# Altium schematic sheet FlipClockController.SchDoc
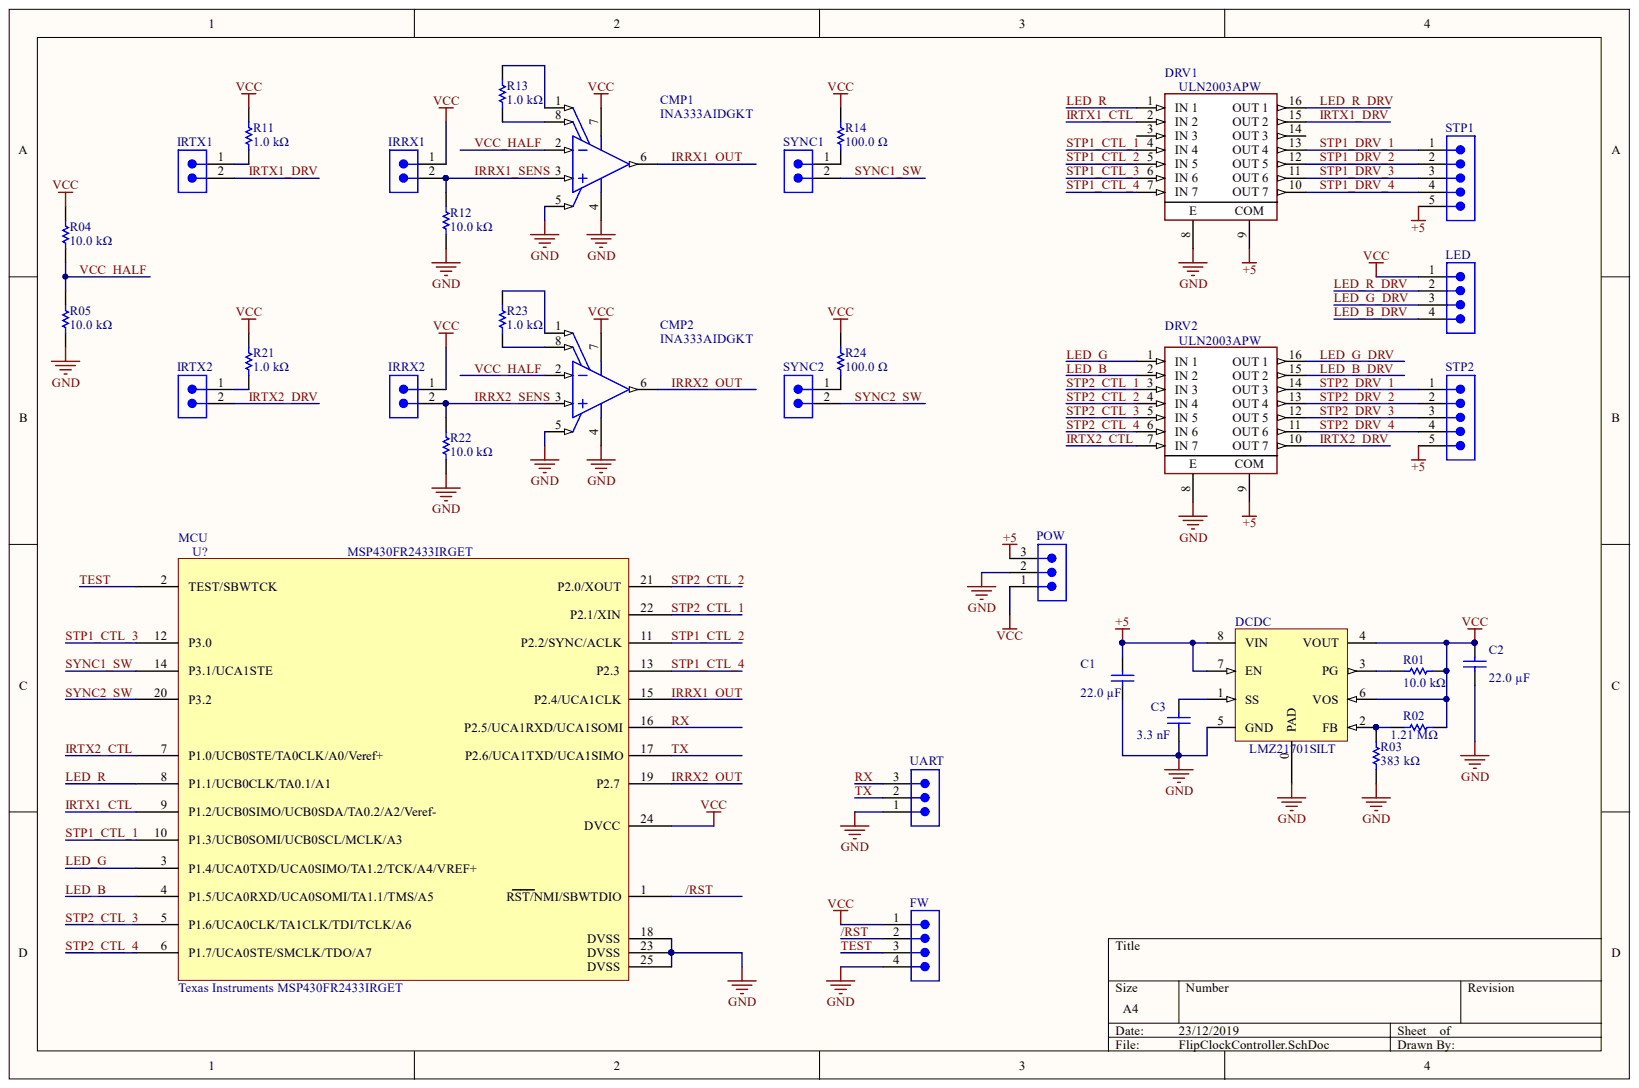
[diagram p0: FlipClockController.SchDoc]

## Parts
C1  Capacitance: 22.0 µF  [PCB-8en9x60tm95q6hri2c8x-1]  (hidden comment: cap 22uF)
C2  cap 22uF  [PCB-8en9x60tm95q6hri2c8x-1]  (value not drawn)
C3  Capacitance: 3.3 nF  [CAPC1608X92X38LL15T08]  (hidden comment: Vitramon VJ0603Y332KXAAC)
CMP1  INA333AIDGKT  [TI-DGK8_L]
CMP2  INA333AIDGKT  [TI-DGK8_L]
DCDC  LMZ21701SILT  [PCB-20086818-1]
DRV1  ULN2003APW  [TSSOP16]
DRV2  ULN2003APW  [TSSOP16]
FW  Samtec TSW-104-05-G-S  [1X4]  (value not drawn)
IRRX1  Samtec TSW-102-05-G-S  [1X2]  (value not drawn)
IRRX2  Samtec TSW-102-05-G-S  [1X2]  (value not drawn)
IRTX1  Samtec TSW-102-05-G-S  [1X2]  (value not drawn)
IRTX2  Samtec TSW-102-05-G-S  [1X2]  (value not drawn)
LED  Samtec TSW-104-05-G-S  [1X4]  (value not drawn)
MCU  Texas Instruments MSP430FR2433IRGET  [PCB-bcs2lmkfqlrqmvty3otx-1]
POW  CMP-668703-2  [SMTC-TSW-103-07-X-S]  (value not drawn)
R01  Resistance: 10.0 kΩ  [RESC1608X55X30LL20T10]  (hidden comment: KOA Speer RK73B1JTTD103J)
R02  Resistance: 1.21 MΩ  [RESC1608X55X25ML10T15]  (hidden comment: Yageo RC0603FR-071M21L)
R03  Resistance: 383 kΩ  [RESC1608X55X25LL10T15]  (hidden comment: Yageo RT0603FRE07383KL)
R04  KOA Speer RK73B1JTTD103J  [RESC1608X55X30LL20T10]  (value not drawn)
R05  KOA Speer RK73B1JTTD103J  [RESC1608X55X30LL20T10]  (value not drawn)
R11  Resistance: 1.0 kΩ  [RESC1608X55X30LL20T10]  (hidden comment: KOA Speer RK73H1JTTD1001F)
R12  KOA Speer RK73B1JTTD103J  [RESC1608X55X30LL20T10]  (value not drawn)
R13  Resistance: 1.0 kΩ  [RESC1608X55X30LL20T10]  (hidden comment: KOA Speer RK73H1JTTD1001F)
R14  KOA Speer RK73H1JTTD1000F  [RESC1608X55X30LL20T10]  (value not drawn)
R21  Resistance: 1.0 kΩ  [RESC1608X55X30LL20T10]  (hidden comment: KOA Speer RK73H1JTTD1001F)
R22  KOA Speer RK73B1JTTD103J  [RESC1608X55X30LL20T10]  (value not drawn)
R23  Resistance: 1.0 kΩ  [RESC1608X55X30LL20T10]  (hidden comment: KOA Speer RK73H1JTTD1001F)
R24  KOA Speer RK73H1JTTD1000F  [RESC1608X55X30LL20T10]  (value not drawn)
STP1  Samtec TSW-105-05-G-S  [1X5]  (value not drawn)
STP2  Samtec TSW-105-05-G-S  [1X5]  (value not drawn)
SYNC1  Samtec TSW-102-05-G-S  [1X2]  (value not drawn)
SYNC2  Samtec TSW-102-05-G-S  [1X2]  (value not drawn)
UART  TSW-103-07-F-S  [SMTC-TSW-103-07-X-S]  (value not drawn)

## Nets
+5: C1.1 DCDC.7(EN) DCDC.8(VIN) DRV1.9 DRV2.9 POW.3 STP1.5 STP2.5
GND: C1.2 C2.2 C3.2 CMP1.4 CMP1.5 CMP2.4 CMP2.5 DCDC.0(PAD) DCDC.5(GND) DRV1.8(E) DRV2.8(E) FW.4 MCU.18(DVSS) MCU.23(DVSS) MCU.25(DVSS) POW.2 R03.1 R05.1 R12.1 R22.1 UART.1
VCC: C2.1 CMP1.7 CMP2.7 DCDC.4(VOUT) DCDC.6(VOS) FW.1 IRRX1.1 IRRX2.1 LED.1 MCU.24(DVCC) POW.1 R01.1 R02.2 R04.2 R11.2 R14.2 R21.2 R24.2
/RST: FW.2 MCU.1(RST/NMI/SBWTDIO)
IRRX1_OUT: CMP1.6 MCU.15(P2.4/UCA1CLK)
IRRX1_SENS: CMP1.3 IRRX1.2 R12.2
IRRX2_OUT: CMP2.6 MCU.19(P2.7)
IRRX2_SENS: CMP2.3 IRRX2.2 R22.2
IRTX1_CTL: DRV1.2(IN_2) MCU.9(P1.2/UCB0SIMO/UCB0SDA/TA0.2/A2/Veref-)
IRTX1_DRV: DRV1.15(OUT_2) IRTX1.2
IRTX2_CTL: DRV2.7(IN_7) MCU.7(P1.0/UCB0STE/TA0CLK/A0/Veref+)
IRTX2_DRV: DRV2.10(OUT_7) IRTX2.2
LED_B: DRV2.2(IN_2) MCU.4(P1.5/UCA0RXD/UCA0SOMI/TA1.1/TMS/A5)
LED_B_DRV: DRV2.15(OUT_2) LED.4
LED_G: DRV2.1(IN_1) MCU.3(P1.4/UCA0TXD/UCA0SIMO/TA1.2/TCK/A4/VREF+)
LED_G_DRV: DRV2.16(OUT_1) LED.3
LED_R: DRV1.1(IN_1) MCU.8(P1.1/UCB0CLK/TA0.1/A1)
LED_R_DRV: DRV1.16(OUT_1) LED.2
RX: MCU.16(P2.5/UCA1RXD/UCA1SOMI) UART.3
STP1_CTL_1: DRV1.4(IN_4) MCU.10(P1.3/UCB0SOMI/UCB0SCL/MCLK/A3)
STP1_CTL_2: DRV1.5(IN_5) MCU.11(P2.2/SYNC/ACLK)
STP1_CTL_3: DRV1.6(IN_6) MCU.12(P3.0)
STP1_CTL_4: DRV1.7(IN_7) MCU.13(P2.3)
STP1_DRV_1: DRV1.13(OUT_4) STP1.1
STP1_DRV_2: DRV1.12(OUT_5) STP1.2
STP1_DRV_3: DRV1.11(OUT_6) STP1.3
STP1_DRV_4: DRV1.10(OUT_7) STP1.4
STP2_CTL_1: DRV2.3(IN_3) MCU.22(P2.1/XIN)
STP2_CTL_2: DRV2.4(IN_4) MCU.21(P2.0/XOUT)
STP2_CTL_3: DRV2.5(IN_5) MCU.5(P1.6/UCA0CLK/TA1CLK/TDI/TCLK/A6)
STP2_CTL_4: DRV2.6(IN_6) MCU.6(P1.7/UCA0STE/SMCLK/TDO/A7)
STP2_DRV_1: DRV2.14(OUT_3) STP2.1
STP2_DRV_2: DRV2.13(OUT_4) STP2.2
STP2_DRV_3: DRV2.12(OUT_5) STP2.3
STP2_DRV_4: DRV2.11(OUT_6) STP2.4
SYNC1_SW: MCU.14(P3.1/UCA1STE) SYNC1.2
SYNC2_SW: MCU.20(P3.2) SYNC2.2
TEST: FW.3 MCU.2(TEST/SBWTCK)
TX: MCU.17(P2.6/UCA1TXD/UCA1SIMO) UART.2
VCC_HALF: CMP1.2 CMP2.2 R04.1 R05.2
NetC3_1: C3.1 DCDC.1(SS)
NetCMP1_1: CMP1.1 R13.1
NetCMP1_8: CMP1.8 R13.2
NetCMP2_1: CMP2.1 R23.1
NetCMP2_8: CMP2.8 R23.2
NetDCDC_2: DCDC.2(FB) R02.1 R03.2
NetDCDC_3: DCDC.3(PG) R01.2
NetIRTX1_1: IRTX1.1 R11.1
NetIRTX2_1: IRTX2.1 R21.1
NetR14_1: R14.1 SYNC1.1
NetR24_1: R24.1 SYNC2.1

Not connected: DRV1 3(IN_3) 14(OUT_3)

## Library data of the parts
C1 = CMP-11774615-7: SMD Multilayer Ceramic Capacitor, GRM Series, 22 F, 20%, X5R, 6.3 V, 0603 [1608 Metric]; Manufacturer Part Number: GRM188R60J226MEA0D; Case/Package (SI): 1608; Lead-Free Status: Lead Free; Voltage Rating (DC): 6.30 V; Capacitance Tolerance: ±20%; Case/Package: 0603; Component Kind: Standard; Library Name: CommunityVault; Lifecycle Status: Active; Mounting Style: Surface Mount; Octopart URL: http://octopart.com/grm188r60j226mea0d-murata-21407286; Packaging: Cut Tape (CT); RoHS: Compliant; Size-Length: 1.60 mm; Size-Width: 800 µm
C2 = CMP-11774615-7: SMD Multilayer Ceramic Capacitor, GRM Series, 22 F, 20%, X5R, 6.3 V, 0603 [1608 Metric]; Manufacturer Part Number: GRM188R60J226MEA0D; Capacitance: 22.0 µF; Case/Package (SI): 1608; Lead-Free Status: Lead Free; Voltage Rating (DC): 6.30 V; Capacitance Tolerance: ±20%; Case/Package: 0603; Component Kind: Standard; Library Name: CommunityVault; Lifecycle Status: Active; Mounting Style: Surface Mount; Octopart URL: http://octopart.com/grm188r60j226mea0d-murata-21407286; Packaging: Cut Tape (CT); RoHS: Compliant; Size-Length: 1.60 mm; Size-Width: 800 µm
C3 = CMP-150069-2: Multilayer Ceramic Capacitors MLCC - SMD/SMT 3300pF 50volts X7R 0.1; Capacitance Tolerance: ±10%; Voltage Rating (DC): 50.0 V; Case/Package: 0603; Component Kind: Standard; Dielectric Characteristic: X7R; Library Name: CommunityVault; Manufacturer Part Number: VJ0603Y332KXAAC; Number of Pins: 2; OctopartID: 4ec085be22f09107; Octopart Url: https://octopart.com/vj0603y332kxaac-vishay+vitramon-41175382; RoHS: Compliant
CMP1 CMP2 = CMP-1154636-2: Low Power, Precision Instrumentation Amplifier, -40 to 125 degC, 8-pin SOP (DGK8), Green (RoHS & no Sb/Br); Bandwidth: 150000.0 Hz; Lead-Free Status: Lead Free; Number of Channels: 1; Quiescent Current: 5e-05 A; Slew Rate: 0.16 V/μs; Component Kind: Standard; Library Name: CommunityVault; Lifecycle Status: Active; Manufacturer Part Number: INA333AIDGKT; Mounting Style: Surface Mount; Number of Pins: 8; OctopartID: 43678a1382239ca0; Octopart Url: https://octopart.com/ina333aidgkt-texas+instruments-8315938; Packaging: Tape & Reel (TR); REACH SVHC Compliance: No SVHC; RoHS: Compliant; Supply Current: 5e-05 A
DCDC = CMP-20086818-9: DC/DC CONVERTER, SYNCHRONOUS BUCK, 2.5MHZ, USIP; DC / DC Converter Type:Non Isolated POL; Input Voltage Min:3V; Input Voltage Max:17V; No. of Outputs:1; DC / DC Converter IC Case: SIP; No. of Pins:8;; Component Kind: Standard; Library Name: CommunityVault
DRV1 DRV2 = CMP-19354101-3: High-Voltage, High-Current Darlington Transistor Arrays 16-TSSOP -20 to 70; Drivers Per Package: 7; Case/Package: PDIP, TSSOP, SOIC; Component Kind: Standard; Library Name: CommunityVault; Mounting Style: Surface Mount; REACH SVHC Compliance: No SVHC; RoHS: Compliant
FW LED = CMP-4485475-2: CONN HEADER 4POS .100" SGL GOLD; Gender: Male; Lead-Free Status: Lead Free; Number of Contacts: 4; Pin Pitch: 0.00254 m; Component Kind: Standard; Contact Material: Phosphor Bronze; Contact Plating: Gold; Housing Color: Black; Housing Material: Plastic; Library Name: CommunityVault; Manufacturer Part Number: TSW-104-05-G-S; Mounting Style: Through Hole; Number of Positions: 4; Number of Rows: 1; OctopartID: 1a1ed3101b5fc5d9; Octopart Url: https://octopart.com/tsw-104-05-g-s-samtec-271399; REACH SVHC Compliance: No SVHC; RoHS: Compliant; Size-Height: 0.00254 m; Size-Length: 0.01016 m; Size-Width: 0.00254 m
IRRX1 IRRX2 IRTX1 IRTX2 SYNC1 SYNC2 = CMP-4500545-3: CONN HEADER 2POS .100" SGL GOLD; Gender: Male; Lead-Free Status: Lead Free; Number of Contacts: 2; Pin Pitch: 0.00254 m; Component Kind: Standard; Contact Material: Phosphor Bronze; Contact Plating: Gold; Housing Color: Black; Housing Material: Plastic; Library Name: CommunityVault; Manufacturer Part Number: TSW-102-05-G-S; Mounting Style: Through Hole; Number of Positions: 2; Number of Rows: 1; OctopartID: cadc03d2cb9f151b; Octopart Url: https://octopart.com/tsw-102-05-g-s-samtec-271397; REACH SVHC Compliance: No SVHC; RoHS: Compliant; Size-Height: 0.00254 m; Size-Length: 0.00508 m; Size-Width: 0.00254 m
MCU = CMP-694ea3beafcfb689-8: 16 MHz Ultra-Low-Power Microcontroller with 16 KB FRAM, 4 KB RAM, 10-bit ADC, 19 IO, 4 16-bit Timers 24-VQFN -40 to 85; Frequency: 16.0 MHz; Lead-Free Status: Lead Free; RAM Memory Size: 19968 B; Component Kind: Standard; Library Name: CommunityVault; Lifecycle Status: Pre-Release; Mounting Style: Surface Mount; OctopartID: 694ea3beafcfb689; Operating Temperature: -40.0 °C to 85.0 °C; RoHS: Compliant
POW = CMP-668703-2: 0.025" SQ Post Header, Through-hole, Vertical, -55 to 125 degC, 2.54 mm Pitch, 3-Pin, Male, RoHS; Manufacturer Part Number: TSW-103-07-F-S; Number of Contacts: 3; Pin Pitch: 0.00254 m; Component Kind: Standard; Contact Material: Phosphor Bronze; Contact Plating: Gold; Gender: Male; Library Name: CommunityVault; Mounting Style: Through Hole; Number of Rows: 1; OctopartID: 98e25129adb64725; Octopart Url: https://octopart.com/tsw-103-07-f-s-samtec-12652594; REACH SVHC Compliance: No SVHC; RoHS: Compliant
R01 = CMP-2823407-2: Res Thick Film 0603 10K Ohm 5% 1/10W ±200ppm/C Molded SMD Punched Paper T/R; Lead-Free Status: Lead Free; Power Rating: 0.1 W; Temperature Coefficient: 200.0 ppm/°C; Voltage Rating (DC): 50.0 V; Case/Package: 0603; Component Kind: Standard; Library Name: CommunityVault; Manufacturer Part Number: RK73B1JTTD103J; Mounting Style: Surface Mount; OctopartID: a3d76afc89477c10; Octopart Url: https://octopart.com/rk73b1jttd103j-koa+speer-2166774; Resistance Tolerance: ±5%; RoHS: Compliant; Size-Length: 0.0016 m; Size-Width: 0.0008 m
R02 = CMP-1853549-2: Thick Film Resistors 1.21M OHM 1%; Lead-Free Status: Lead Free; Power Rating: 0.1 W; Voltage Rating (DC): 50.0 V; Case/Package: 0603; Component Kind: Standard; Library Name: CommunityVault; Manufacturer Part Number: RC0603FR-071M21L; Mounting Style: Surface Mount; OctopartID: a0e869f7dbd07f2f; Octopart Url: https://octopart.com/rc0603fr-071m21l-yageo-1400414; Resistance Tolerance: ±1%; RoHS: Compliant; Size-Height: 0.00045 m; Size-Length: 0.0016 m; Size-Width: 0.0008 m
R03 = CMP-7962353-2: Thin Film Resistors 1/10W 383K ohm 1% 50ppm; Case/Package: 0603; Component Kind: Standard; Library Name: CommunityVault; Manufacturer Part Number: RT0603FRE07383KL; Mounting Style: Surface Mount; OctopartID: 307af8f70362efbd; Octopart Url: https://octopart.com/rt0603fre07383kl-yageo-25630334; Resistance Tolerance: ±1%; RoHS: Compliant
R04 R05 R12 R22 = CMP-2823407-2: Res Thick Film 0603 10K Ohm 5% 1/10W ±200ppm/C Molded SMD Punched Paper T/R; Lead-Free Status: Lead Free; Power Rating: 0.1 W; Resistance: 10.0 kΩ; Temperature Coefficient: 200.0 ppm/°C; Voltage Rating (DC): 50.0 V; Case/Package: 0603; Component Kind: Standard; Library Name: CommunityVault; Manufacturer Part Number: RK73B1JTTD103J; Mounting Style: Surface Mount; OctopartID: a3d76afc89477c10; Octopart Url: https://octopart.com/rk73b1jttd103j-koa+speer-2166774; Resistance Tolerance: ±5%; RoHS: Compliant; Size-Length: 0.0016 m; Size-Width: 0.0008 m
R11 R13 R21 R23 = CMP-2823528-2: Res Thick Film 0603 1K Ohm 1% 0.1W(1/10W) ±100ppm/C Molded SMD Automotive Punched Paper T/R; Lead-Free Status: Lead Free; Temperature Coefficient: 100.0 ppm/°C; Voltage Rating (DC): 50.0 V; Case/Package: 0603; Component Kind: Standard; Library Name: CommunityVault; Manufacturer Part Number: RK73H1JTTD1001F; Mounting Style: Surface Mount; OctopartID: e6af2f84cdaf3968; Octopart Url: https://octopart.com/rk73h1jttd1001f-koa+speer-2166374; Power Rating: 0.1 W; Resistance Tolerance: ±1%; RoHS: Compliant; Size-Length: 0.0016 m; Size-Width: 0.0008 m
R14 R24 = CMP-2823347-2: Res Thick Film 0603 100 Ohm 1% 0.1W(1/10W) ±100ppm/C Molded SMD Automotive Punched Paper T/R; Lead-Free Status: Lead Free; Resistance: 100.0 Ω; Temperature Coefficient: 100.0 ppm/°C; Voltage Rating (DC): 50.0 V; Case/Package: 0603; Component Kind: Standard; Library Name: CommunityVault; Manufacturer Part Number: RK73H1JTTD1000F; Mounting Style: Surface Mount; OctopartID: 224beb600e0cfd8c; Octopart Url: https://octopart.com/rk73h1jttd1000f-koa+speer-2165025; Power Rating: 0.1 W; Resistance Tolerance: ±1%; RoHS: Compliant; Size-Length: 0.0016 m; Size-Width: 0.0008 m
STP1 STP2 = CMP-3921831-3: CONN HEADER 5POS .100" SGL GOLD; Gender: Male; Lead-Free Status: Lead Free; Number of Contacts: 5; Pin Pitch: 0.00254 m; Component Kind: Standard; Contact Material: Phosphor Bronze; Contact Plating: Gold; Housing Color: Black; Housing Material: Plastic; Library Name: CommunityVault; Manufacturer Part Number: TSW-105-05-G-S; Mounting Style: Through Hole; Number of Positions: 5; Number of Rows: 1; OctopartID: 4c1985174d5793de; Octopart Url: https://octopart.com/tsw-105-05-g-s-samtec-271400; REACH SVHC Compliance: No SVHC; RoHS: Compliant; Size-Height: 0.00254 m; Size-Length: 0.0127 m; Size-Width: 0.00254 m
UART = TSW-103-07-F-S: 0.025" SQ Post Header, Through-hole, Vertical, -55 to 125 degC, 2.54 mm Pitch, 3-Pin, Male, RoHS; Number of Contacts: 3; Pin Pitch: 0.00254 m; Component Kind: Standard; Contact Material: Phosphor Bronze; Contact Plating: Gold; Gender: Male; Library Name: Octopart library; Manufacturer Part Number: TSW-103-07-F-S; Mounting Style: Through Hole; Number of Rows: 1; OctopartID: 98e25129adb64725; Octopart Url: https://octopart.com/tsw-103-07-f-s-samtec-12652594; REACH SVHC Compliance: No SVHC; RoHS: Compliant
